# Altium schematic sheet SWD_Adapter.SchDoc(SWD_Adapter)
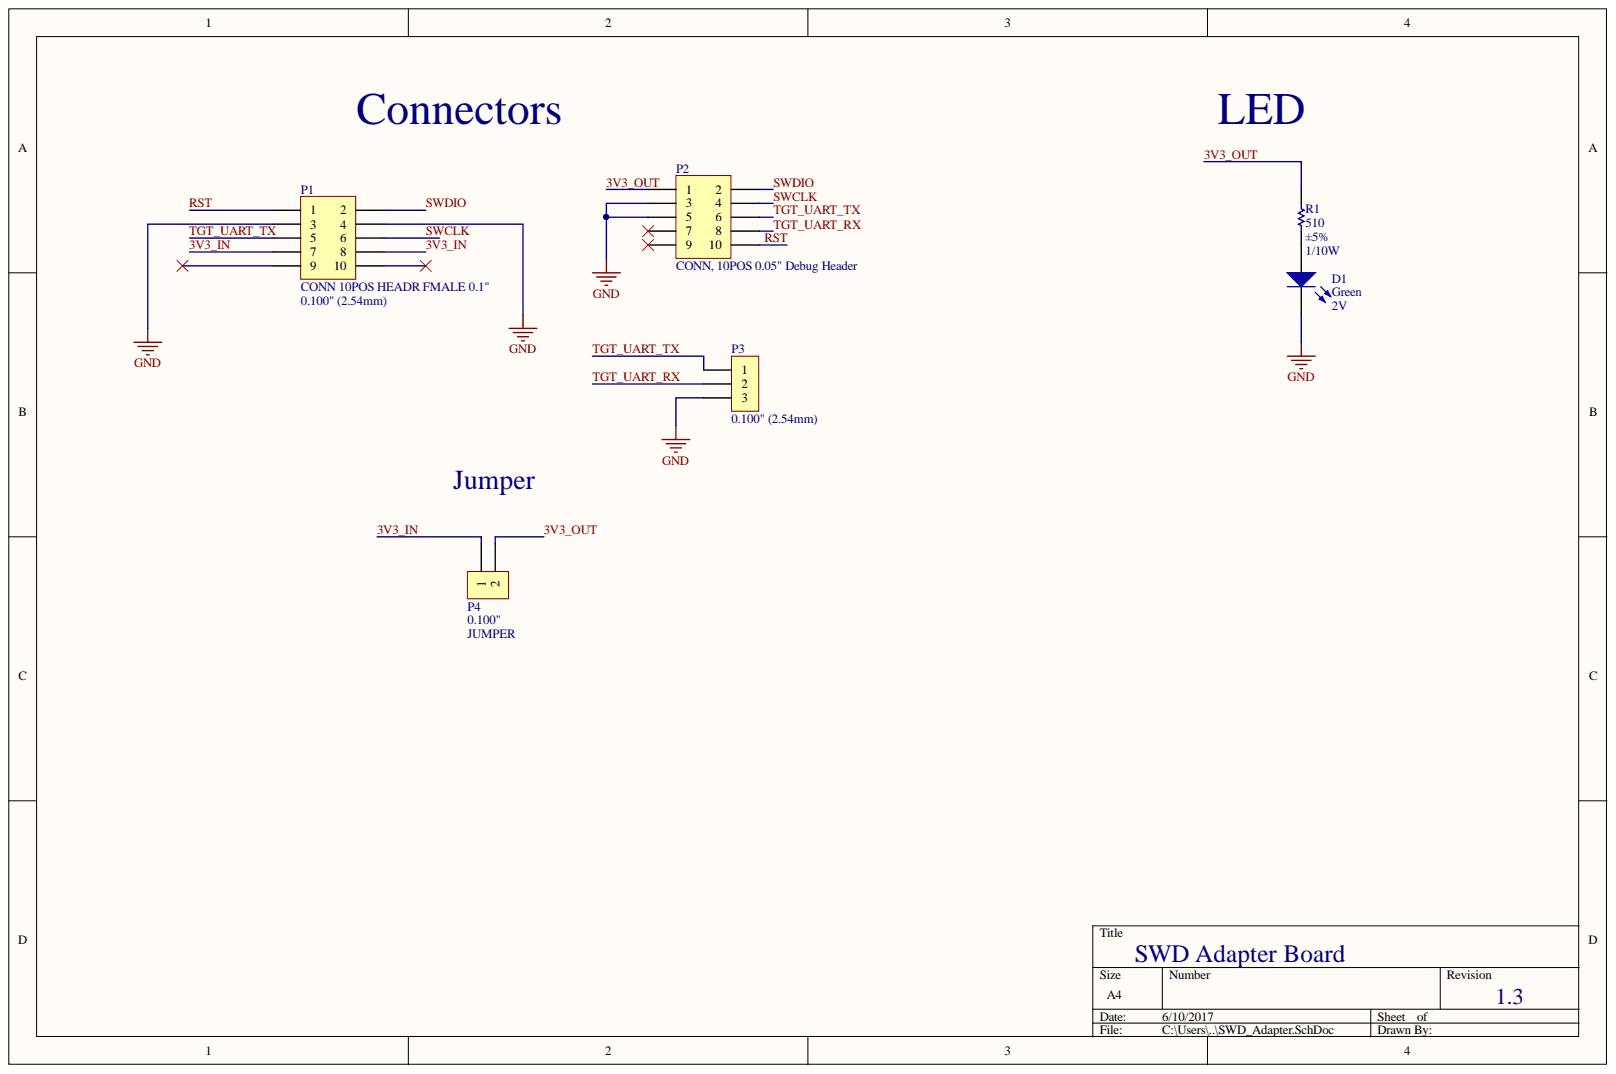
[diagram p0: SWD_Adapter.SchDoc]

## Parts
D1  Color: Green  Voltage - Forward (Vf) (Typ): 2V  [LED_0603]
P1  CONN 10POS HEADR FMALE 0.1"  Pitch - Mating: 0.100" (2.54mm)  [CONN ,10 POS Header 0.100"]
P2  CONN, 10POS 0.05" Debug Header  [CONN, 10POS 0.05" Debug Header]
P3  Insulation Height: 0.100" (2.54mm)  [CONN, 3POS 0.100" Header, 1 ROW]  (hidden comment: CONN 3POS HEADR MALE 0.1")
P4  JUMPER  Row Spacing - Mating: 0.100"  [CONN 2POS JUMPER 0.1"]
R1  Power: 1/10W  Tolerance: ±5%  [RES, 0603]  (hidden comment: RES 510 OHM 5% 1/10W 0603)

## Nets
GND: D1.2 P1.3 P1.4 P2.3 P2.5 P3.3
3V3_IN: P1.7 P1.8 P4.1
3V3_OUT: P2.1 P4.2 R1.1
RST: P1.1 P2.10
SWCLK: P1.6 P2.4
SWDIO: P1.2 P2.2
TGT_UART_RX: P2.8 P3.2
TGT_UART_TX: P1.5 P2.6 P3.1
NetD1_1: D1.1 R1.2

Not connected: P1 9 10; P2 7 9

## Library data of the parts
D1 = LED GREEN CLEAR 2V 0603: Green 572nm LED Indication - Discrete 2V 0603 (1608 Metric); Manufacturer: Wurth Electronics Inc.; Manufacturer Part Number: 150060VS75000; Current - Test: 20mA; Lens Color: Colorless; Lens Style/Size: Rectangle with Flat Top, 1.10mm x 0.70mm; Lens Transparency: Clear; Millicandela Rating: 40mcd; Viewing Angle: 140°; Wavelength - Dominant: 570nm; Category: Optoelectronics; Component Kind: Standard; ComponentLink1Description: http://katalog.we-online.de/led/datasheet/150060VS75000.pdf; ComponentLink1URL: http://katalog.we-online.de/led/datasheet/150060VS75000.pdf; Features: -; Height (Max): 0.80mm; Mounting Type: Surface Mount; Package / Case: 0603 (1608 Metric); Packaging: Cut Tape (CT); Part Status: Active; Pricing 1: 1=0.21, 100=0.1617, 500=0.13964 (CAD); RoHS: RoHS Compliant; Size / Dimension: 1.60mm L x 0.80mm W; Stock 1: 9408; Supplier 1: Digi-Key; Supplier Device Package: 0603; Supplier Part Number 1: 732-4980-1-ND; Wavelength - Peak: 572nm; Library Name: Schematic Diagrams.SchLib
P1 = CONN 10POS HEADR FMALE 0.1": 10 Position Header Connector 0.100" (2.54mm) Through Hole, Right Angle Gold; Manufacturer 1: Sullins Connector Solutions; Manufacturer Part Number 1: SFH11-PBPC-D05-RA-BK; Contact Length - Post: 0.106" (2.69mm); Current Rating: 3A; Material Flammability Rating: UL94 V-0; Number of Positions: 10; Number of Positions Loaded: All; Style: Board to Board; Component Kind: Standard; ComponentLink1Description: http://sullinscorp.com/catalogs/147_PAGE119_.100_SFH11_SERIES_FEMALE_HDR_ST_RA.pdf; ComponentLink1URL: http://sullinscorp.com/catalogs/147_PAGE119_.100_SFH11_SERIES_FEMALE_HDR_ST_RA.pdf; Connector Type: Header; Contact Finish - Mating: Gold; Contact Finish - Post: Gold; Contact Finish Thickness - Mating: Flash; Contact Finish Thickness - Post: Flash; Contact Material: Brass; Contact Shape: Square; Contact Type: Female Socket; Fastening Type: Push-Pull; Ingress Protection: -; Insulation Color: Black; Insulation Height: 0.236" (6.00mm); Insulation Material: Polybutylene Terephthalate (PBT); Mated Stacking Heights: -; Mounting Type: Through Hole, Right Angle; Number of Rows: 2; Operating Temperature: -40°C ~ 105°C; Packaging: Tray; Part Status: Active; Row Spacing - Mating: 0.100" (2.54mm); Supplier 1: Digi-Key; Supplier Part Number 1: S9202-ND; Termination: Solder; Voltage: -; Library Name: Schematic Diagrams.SchLib
P2 = CONN, 10POS 0.05" Debug Header 20021121-00010C4LF: 10 Positions Header Connector 0.050" (1.27mm) Surface Mount Gold; Manufacturer: Amphenol FCI; Component Kind: Standard; Manufacturer Part Number: 20021121-00010C4LF; Supplier: Digikey; Supplier 1: Digi-Key; SupplierPartNumber: 609-3695-1-ND; Supplier Part Number 1: 609-3695-1-ND; Library Name: Schematic Diagrams.SchLib
P3 = CONN 3POS HEADR MALE 0.1": 3 Positions Header Connector 0.100" (2.54mm) Through Hole Gold; Manufacturer 1: Wurth Electronics Inc.; Manufacturer Part Number 1: 61300311121; Contact Length - Mating: 0.236" (6.00mm); Contact Length - Post: 0.118" (3.00mm); Current Rating: 3A; Material Flammability Rating: UL94 V-0; Number of Positions: 3; Number of Positions Loaded: All; Overall Contact Length: 0.454" (11.54mm); Shrouding: Unshrouded; Style: Board to Board or Cable; Voltage: 250V; Component Kind: Standard; ComponentLink1Description: http://katalog.we-online.de/em/datasheet/6130xx11121.pdf; ComponentLink1URL: http://katalog.we-online.de/em/datasheet/6130xx11121.pdf; Connector Type: Header; Contact Finish - Mating: Gold; Contact Finish - Post: -; Contact Finish Thickness - Mating: -; Contact Finish Thickness - Post: -; Contact Material: Copper Alloy; Contact Shape: Square; Contact Type: Male Pin; Fastening Type: -; Ingress Protection: -; Insulation Color: Black; Insulation Material: Polybutylene Terephthalate (PBT); Mated Stacking Heights: -; Mounting Type: Through Hole; Number of Rows: 1; Operating Temperature: -40°C ~ 125°C; Packaging: Bulk; Part Status: Active; Pitch - Mating: 0.100" (2.54mm); Row Spacing - Mating: -; Supplier 1: Digi-Key; Supplier Part Number 1: 732-5316-ND; Termination: Solder; Library Name: Schematic Diagrams.SchLib
P4 = CONN 2POS JUMPER 0.1": 2 Positions Jumper; Manufacturer: Omron Electronics Inc-EMC Div; Manufacturer Part Number: XG8T-0231; Connector Type: Header; Contact Length - Mating: 0.169" (4.30mm); Contact Length - Post: 0.118" (3.00mm); Current Rating: 2A; Material Flammability Rating: UL94 V-0; Number of Positions: 2; Number of Positions Loaded: All; Overall Contact Length: 0.386" (9.80mm); Shrouding: Unshrouded; Style: Board to Board or Cable; Voltage Rating: 300V; Applications: -; Category: Connectors, Interconnects; Component Kind: Standard; ComponentLink1Description: http://www.omron.com/ecb/products/pdf/en-xj8.pdf; ComponentLink1URL: http://www.omron.com/ecb/products/pdf/en-xj8.pdf; Contact Finish - Mating: Gold; Contact Finish - Post: Tin; Contact Finish Thickness - Mating: 5.9µin (0.15µm); Contact Finish Thickness - Post: -; Contact Material: Brass, Nickel; Contact Shape: Square; Contact Type: Male Pin; Fastening Type: -; Features: -; Ingress Protection: -; Insulation Color: Black; Insulation Height: 0.098" (2.50mm); Insulation Material: Polybutylene Terephthalate (PBT), Glass Filled; Mated Stacking Heights: -; Mounting Type: Through Hole; Number of Rows: 2; Operating Temperature: -55°C ~ 105°C; Packaging: Bulk; Part Status: Active; Pitch - Mating: -; Pricing 1: 1=0.28, 10=0.265, 100=0.183, 500=0.15484, 1000=0.12668, 5000=0.11261, 10000=0.10205 (CAD); RoHS: RoHS Compliant; Stock 1: 9094; Supplier 1: Digi-Key; Supplier Part Number 1: XG8T-0231-ND; Termination: Solder; Type: JUMPER; Library Name: Schematic Diagrams.SchLib
R1 = RES 510 OHM 5% 1/10W 0603: RES SMD 510 OHM 5% 1/10W 0603; Manufacturer 1: Yageo; Manufacturer Part Number 1: RC0603JR-07510RL; Resistance: 510; Temperature Coefficient: ±100ppm/°C; Component Kind: Standard; ComponentLink1Description: http://www.yageo.com/documents/recent/PYu-RC_Group_51_RoHS_L_7.pdf; ComponentLink1URL: http://www.yageo.com/documents/recent/PYu-RC_Group_51_RoHS_L_7.pdf; Composition: Thick Film; Failure Rate: -; Height - Seated (Max): 0.022" (0.55mm); Operating Temperature: -55°C ~ 155°C; Package / Case: 0603 (1608 Metric); Packaging: Cut Tape (CT); Part Status: Active; Size / Dimension: 0.063" L x 0.031" W (1.60mm x 0.80mm); Supplier 1: Digi-Key; Supplier Device Package: 0603; Supplier Part Number 1: 311-510GRCT-ND; Library Name: Schematic Diagrams.SchLib
